# Altium schematic sheet PMIC.SchDoc(PMIC)
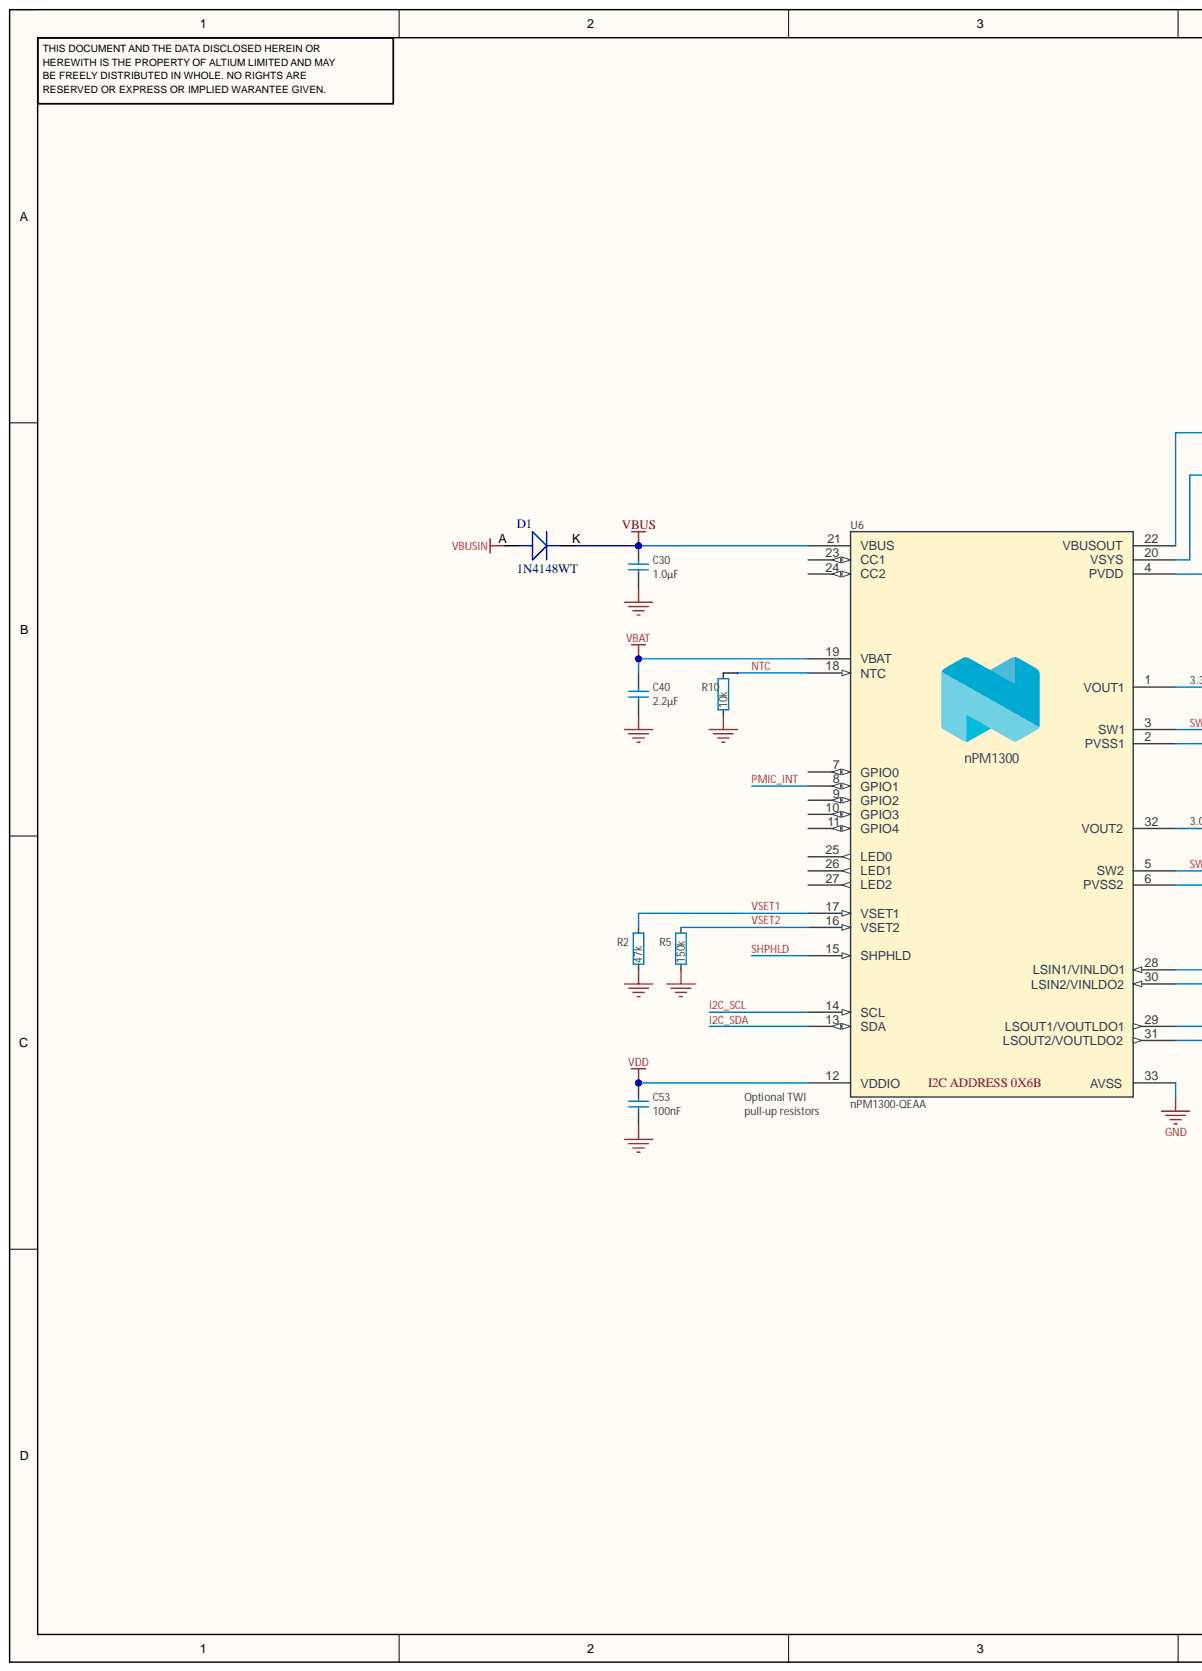
[diagram p0: PMIC.SchDoc, left]
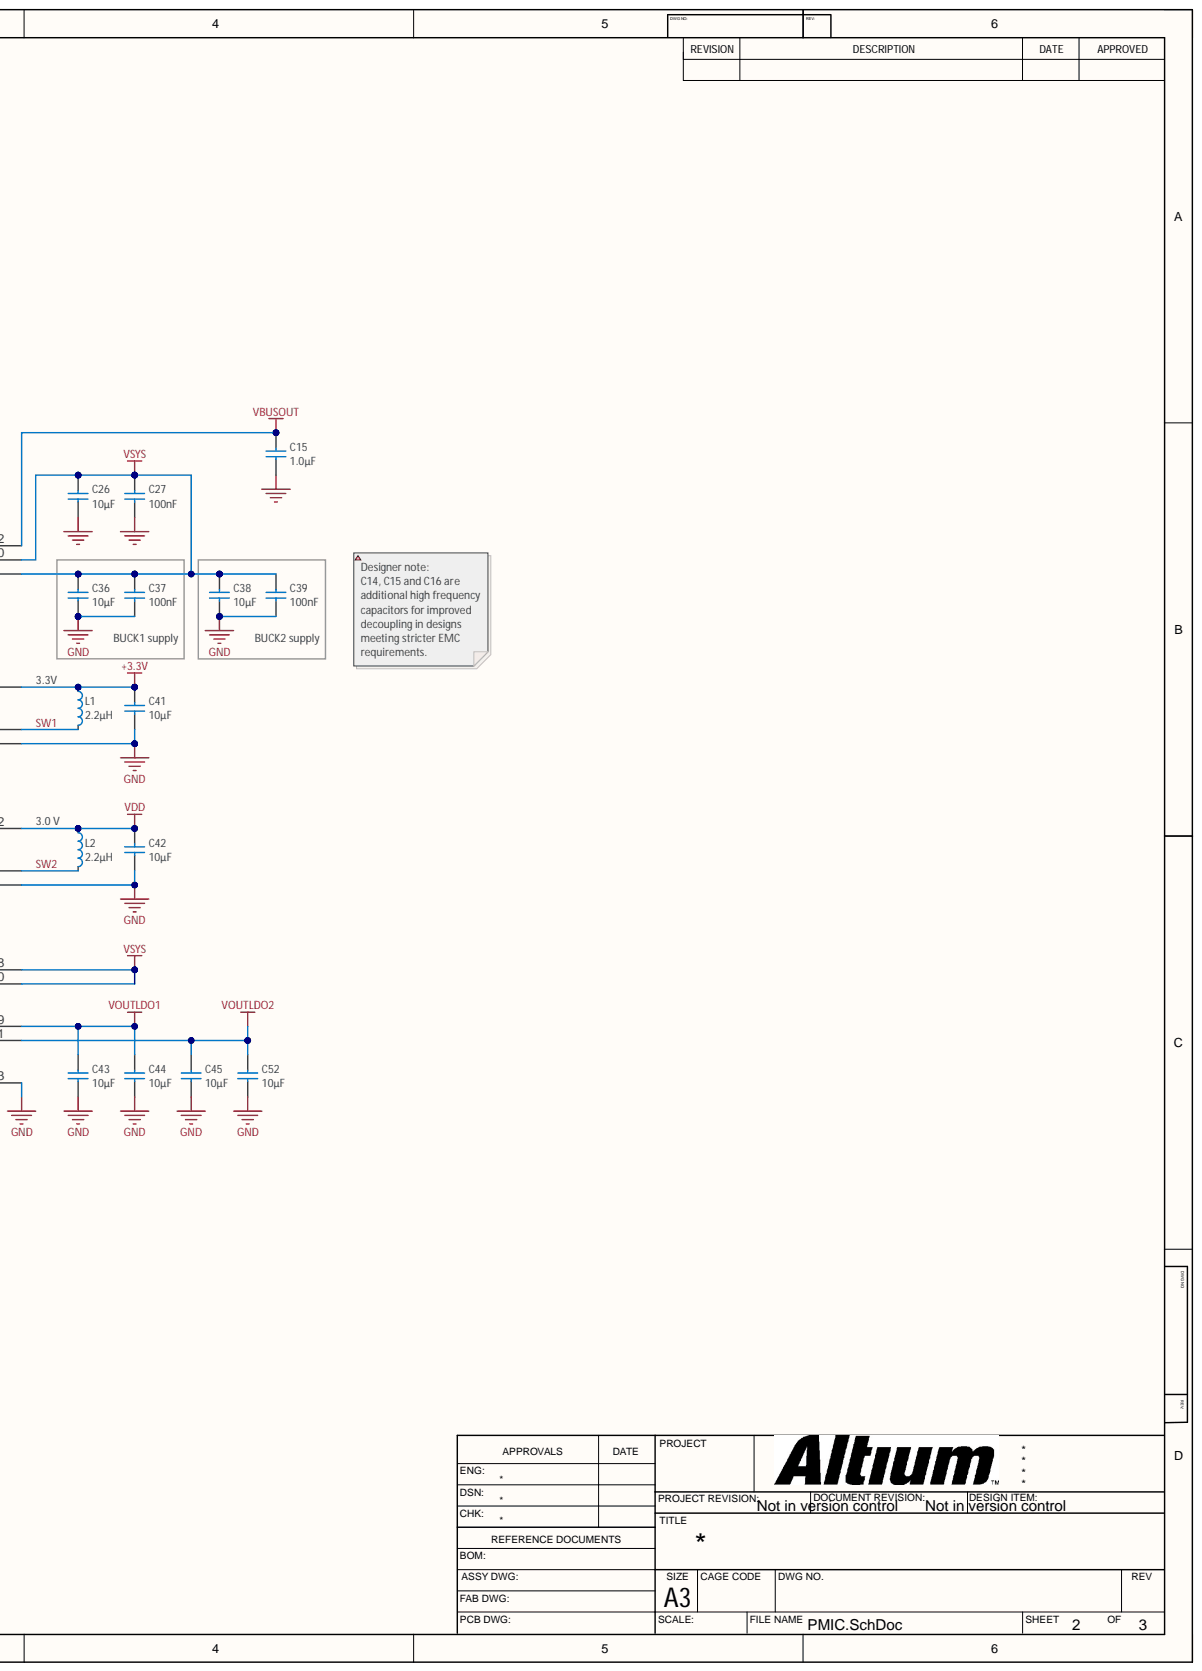
[diagram p1: PMIC.SchDoc, right]

## Parts
C15  1.0µF  [CAP_0402]
C26  10µF  [CAP_0402]
C27  100nF  [CAP_0402]
C30  1.0µF  [CAP_0402]
C36  10µF  [CAP_0402]
C37  100nF  [CAP_0402]
C38  10µF  [CAP_0402]
C39  100nF  [CAP_0402]
C40  2.2µF  [CAP_0402]
C41  10µF  [CAP_0402]
C42  10µF  [CAP_0402]
C43  10µF  [CAP_0402]
C44  10µF  [CAP_0402]
C45  10µF  [CAP_0402]
C52  10µF  [CAP_0402]
C53  100nF  [CAPC0603X03L_C]
D1  1N4148WT  [DIO_SOD523]
L1  2.2µH  [INDC2016X10N]
L2  2.2µH  [INDC2016X10N]
R2  47k  [RES_0402]
R5  150k  [RES_0402]
R10  10k  [RES_0402]
U6  nPM1300-QEAA  [Nordic_QFN50P500X500X90-33N]

## Nets
+3.3V: C41.2 L1.1 U6.1(VOUT1)
GND: C15.1 C26.1 C27.2 C30.1 C36.1 C37.2 C38.1 C39.2 C40.1 C41.1 C42.1 C43.1 C44.1 C45.1 C52.1 C53.2 R2.2 R5.2 R10.2 U6.2(PVSS1) U6.6(PVSS2) U6.33(AVSS)
VBAT: C40.2 U6.19(VBAT)
VBUS: C30.2 D1.K U6.21(VBUS)
VBUSIN: D1.A
VBUSOUT: C15.2 U6.22(VBUSOUT)
VDD: C42.2 C53.1 L2.1 U6.12(VDDIO) U6.32(VOUT2)
VOUTLDO1: C43.2 C44.2 U6.29(LSOUT1/VOUTLDO1)
VOUTLDO2: C45.2 C52.2 U6.31(LSOUT2/VOUTLDO2)
VSYS: C26.2 C27.1 C36.2 C37.1 C38.2 C39.1 U6.4(PVDD) U6.20(VSYS) U6.28(LSIN1/VINLDO1) U6.30(LSIN2/VINLDO2)
I2C_SCL: U6.14(SCL)
I2C_SDA: U6.13(SDA)
NTC: R10.1 U6.18(NTC)
PMIC_INT: U6.8(GPIO1)
SHPHLD: U6.15(SHPHLD)
SW1: L1.2 U6.3(SW1)
SW2: L2.2 U6.5(SW2)
VSET1: R2.1 U6.17(VSET1)
VSET2: R5.1 U6.16(VSET2)

Not connected: U6 7(GPIO0) 9(GPIO2) 10(GPIO3) 11(GPIO4) 23(CC1) 24(CC2) 25(LED0) 26(LED1) 27(LED2)

## Library data of the parts
C15 C30 = C0603-1µ0-10V-X5R: Capacitor, Ceramic, 1.0µF 10V X5R 0603,±10%; Part number NEMS: 100200528; Artikkelnr Noca: N.A.; Component Status: Active; Database Table Name: Parts$; Pad Count: 2; Component Class: Capacitors; Component Kind: Standard; ComponentLink1Description: Datasheet; ComponentLink1URL: N.A.; ComponentLink2Description: Supplier link; ComponentLink2URL: N.A.; Footprint Doc: 0603; HelpURL: N.A.; LatestRevisionDate: 2022-10-06; Library Name: AltiumLib.DbLib; Manufacturer: N.A.; Manufacturer Part Number: N.A.; Note: 10V; Part Number: C0603-1µ0-10V-X5R; Part number IPS: N.A.; Part number Norautron: N.A.; PartId: 100200528; Published: 2022-10-06; Supplier 1: N.A.; Supplier 2: N.A.; Supplier Part Number 1: N.A.; Supplier Part Number 2: N.A.; Value: 1.0µF; Author: getr
C26 C36 C38 C41-C45 C52 = C0603-10µ: Capacitor, X5R, ±20%; Manufacturer: N.A.; Part number IPS: 00000007785; Part number NEMS: 100200275; Artikkelnr Noca: 20039234; Component Status: Active; Database Table Name: Parts$; Note: 6.3V; Pad Count: 2; Component Class: Capacitors; Component Kind: Standard; ComponentLink1Description: Datasheet; ComponentLink1URL: N.A.; ComponentLink2Description: Supplier link; ComponentLink2URL: N.A.; Footprint Doc: 0603; HelpURL: N.A.; LatestRevisionDate: 2011-01-17; Library Name: AltiumLib.DbLib; Manufacturer Part Number: N.A.; Part Number: C0603-10µ; Part number Norautron: N.A.; PartId: 100200275; Published: 2011-01-17; Supplier 1: N.A.; Supplier 2: N.A.; Supplier Part Number 1: N.A.; Supplier Part Number 2: N.A.; Value: 10µF; Author: rsk
C27 C37 C39 = C0402-100n-16V-X7R-C0402C104M4RAC7867: Capacitor, Ceramic, X7R, ±20%, 16V; Manufacturer: Kemet; Part number NEMS: 100200504; Artikkelnr Noca: N.A.; Component Status: Active; Database Table Name: Parts$; Pad Count: 2; Component Class: Capacitors; Component Kind: Standard; ComponentLink1Description: Datasheet; ComponentLink1URL: https://api.kemet.com/component-edge/download/datasheet/C0402C104M4RACTU.pdf; ComponentLink2Description: Supplier link; ComponentLink2URL: https://www.digikey.no/no/products/detail/kemet/C0402C104M4RAC7867/2196240?s=N4IgTCBcDaIMIAYAsCxwIzILJIEoEE4B2ADgDYiQBdAXyA; Footprint Doc: 0402; HelpURL: https://www.kemet.com/en/us/capacitors/ceramic/kc-link/product/C0402C104M4RACTU.html; LatestRevisionDate: 2024-03-23; Library Name: AltiumLib.DbLib; Manufacturer Part Number: C0402C104M4RAC7867; Note: 16V; Part Number: C0402-100n-16V-X7R-C0402C104M4RAC7867; Part number IPS: N.A.; Part number Norautron: N.A.; PartId: 100200504; Published: 2022-03-23; Supplier 1: Digi-Key; Supplier 2: N.A.; Supplier Part Number 1: 399-C0402C104M4RAC7867CT-ND; Supplier Part Number 2: N.A.; Value: 100nF; Author: getr
C40 = C0603-2.2µ-16V-X7R: Capacitor, Ceramic, X7R, ±10%, 16V; Manufacturer: Taiyo Yuden; Manufacturer Part Number: EMK107BB7225KA-T; Part number NEMS: 100200439; Artikkelnr Noca: N.A.; Component Status: Active; Database Table Name: Parts$; Pad Count: 2; Component Class: Capacitors; Component Kind: Standard; ComponentLink1Description: Datasheet; ComponentLink1URL: https://ds.yuden.co.jp/TYCOMPAS/ut/specSheet?pn=EMK107BB7225KA-T; ComponentLink2Description: Supplier link; ComponentLink2URL: https://ds.yuden.co.jp/TYCOMPAS/ut/detail?pn=EMK107BB7225KA-T&u=M; Footprint Doc: 0603; HelpURL: https://www.digikey.no/product-detail/en/taiyo-yuden/EMK107BB7225KA-T/587-5835-2-ND/4970646; LatestRevisionDate: 2020-10-13; Library Name: AltiumLib.DbLib; Note: 16V; Part Number: C0603-2.2µ-16V-X7R; Part number IPS: N.A.; Part number Norautron: N.A.; PartId: 100200439; Published: 2020-02-03; Supplier 1: Digi-Key; Supplier 2: N.A.; Supplier Part Number 1: 587-5835-2-ND; Supplier Part Number 2: N.A.; Value: 2.2µF; Author: rsk
C53 = C0201-100n: Capacitor, X5R, ±10%, 100nF, 16V; Part number IPS: 00000001248, 00000002505; Part number NEMS: 100200078; Part number Norautron: 262071862; Artikkelnr Noca: N.A.; Component Status: Active; Database Table Name: Parts$; Pad Count: 2; Component Class: Capacitors; Component Kind: Standard; ComponentLink1Description: Datasheet; ComponentLink1URL: N.A.; ComponentLink2Description: Supplier link; ComponentLink2URL: N.A.; Footprint Doc: 0201; HelpURL: N.A.; LatestRevisionDate: 2024-06-27; Library Name: AltiumLib.DbLib; Manufacturer: N.A.; Manufacturer Part Number: N.A.; Note: 16V; Part Number: C0201-100n; PartId: 100200078; Published: 2014-11-13; Supplier 1: N.A.; Supplier 2: N.A.; Supplier Part Number 1: N.A.; Supplier Part Number 2: N.A.; Value: 100nF; Author: rsk
D1 = DIO_75V-200mA-SOD-523F: Diode Standard 100V 300mA (DC) Surface Mount SOD-123; Manufacturer: FAIRCHILD; Case/Package: SOD123; Component Kind: Standard; Manufacturer Part Number: 1N4148WT; Supplier-1: SIRICOM; Library Name: EsteemPCB_SOM_Course_schlib.SchLib
L1 L2 = L0806-2µ2-2500mA-73mOhm-CIGT201610EH2R2MNE: Inductor, Irms=2.5A, Isat=2.9A, ±20%, DCR=73mΩ; Manufacturer: Samsung Electro-Mechanics America; Part number NEMS: 100300400; Part number Norautron: 26521789; Artikkelnr Noca: N.A.; Component Status: Active; Database Table Name: Parts$; Note: Unshielded Thin Film Inductor; Pad Count: 2; Component Class: Inductors; Component Kind: Standard; ComponentLink1Description: Datasheet; ComponentLink1URL: https://media.digikey.com/pdf/Data%20Sheets/Samsung%20PDFs/CIGT201610EH2R2MNE_Spec.pdf; ComponentLink2Description: Supplier link; ComponentLink2URL: https://www.digikey.com/en/products/detail/samsung-electro-mechanics/CIGT201610EH2R2MNE/7041223?s=N4IgTCBcDaIMIEkDiAVMAGAjANk%2BgogBJgBKYAsgHL4gC6AvkA; Footprint Doc: 0806; HelpURL: http://product.samsungsem.com/pi/CIGT201610EH2R2MN.do; LatestRevisionDate: 2022-09-07; Library Name: AltiumLib.DbLib; Manufacturer Part Number: CIGT201610EH2R2MNE; Part Number: L0806-2µ2-2500mA-73mOhm-CIGT201610EH2R2MNE; Part number IPS: N.A.; PartId: 100300400; Published: 2021-02-03; Supplier 1: Digi-Key; Supplier 2: Mouser; Supplier Part Number 1: 1276-6901-1-ND; Supplier Part Number 2: 187-CIGT201610EH2R2M; Value: 2.2µH; Author: rsk
R2 = R0201-47k: Resistor, ±1%, 0.05W; Part number IPS: 00000001423; Part number NEMS: 100100076; Artikkelnr Noca: N.A.; Component Status: Active; Database Table Name: Parts$; Note: Chip Res 1%; Pad Count: 2; Component Class: Resistors; Component Kind: Standard; ComponentLink1Description: Datasheet; ComponentLink1URL: N.A.; ComponentLink2Description: Supplier link; ComponentLink2URL: N.A.; Footprint Doc: 0201; HelpURL: N.A.; LatestRevisionDate: 2014-11-13; Library Name: AltiumLib.DbLib; Manufacturer: N.A.; Manufacturer Part Number: N.A.; Part Number: R0201-47k; Part number Norautron: N.A.; PartId: 100100076; Published: 2014-11-13; Supplier 1: N.A.; Supplier 2: N.A.; Supplier Part Number 1: N.A.; Supplier Part Number 2: N.A.; Value: 47k; Author: rsk
R5 = R0201-150k: Resistor, ±1%, 0.05W; Part number IPS: 00000001422; Part number NEMS: 100100082; Artikkelnr Noca: N.A.; Component Status: Active; Database Table Name: Parts$; Note: Chip Res 1%; Pad Count: 2; Component Class: Resistors; Component Kind: Standard; ComponentLink1Description: Datasheet; ComponentLink1URL: N.A.; ComponentLink2Description: Supplier link; ComponentLink2URL: N.A.; Footprint Doc: 0201; HelpURL: N.A.; LatestRevisionDate: 2014-11-13; Library Name: AltiumLib.DbLib; Manufacturer: N.A.; Manufacturer Part Number: N.A.; Part Number: R0201-150k; Part number Norautron: N.A.; PartId: 100100082; Published: 2014-11-13; Supplier 1: N.A.; Supplier 2: N.A.; Supplier Part Number 1: N.A.; Supplier Part Number 2: N.A.; Value: 150k; Author: rsk
R10 = R0201-10k: Resistor, ±1%, 0.05W; Part number IPS: 00000001255; Part number NEMS: 100100065; Part number Norautron: 26107101; Artikkelnr Noca: N.A.; Component Status: Active; Database Table Name: Parts$; Note: Chip Res 1%; Pad Count: 2; Component Class: Resistors; Component Kind: Standard; ComponentLink1Description: Datasheet; ComponentLink1URL: N.A.; ComponentLink2Description: Supplier link; ComponentLink2URL: N.A.; Footprint Doc: 0201; HelpURL: N.A.; LatestRevisionDate: 2014-11-13; Library Name: AltiumLib.DbLib; Manufacturer: N.A.; Manufacturer Part Number: N.A.; Part Number: R0201-10k; PartId: 100100065; Published: 2014-11-13; Supplier 1: N.A.; Supplier 2: N.A.; Supplier Part Number 1: N.A.; Supplier Part Number 2: N.A.; Value: 10k; Author: rsk
U6 = nPM1300-QEAA: Li-Ion/Li-Polymer USB-C battery charger with high efficiency buck regulators, LDOs or load switches, LED drivers, TWI and VBUSOUT; Manufacturer: Nordic Semiconductor; Part number NEMS: 102000291; Artikkelnr Noca: N.A.; Component Status: Active; Database Table Name: Parts$; Pad Count: 33; Component Class: Nordic_Devices; Component Kind: Standard; ComponentLink1Description: Datasheet; ComponentLink1URL: N.A.; ComponentLink2Description: Supplier link; ComponentLink2URL: N.A.; Footprint Doc: QFN-32; HelpURL: N.A.; LatestRevisionDate: 2023-05-25; Library Name: AltiumLib.DbLib; Manufacturer Part Number: nPM1300-QEAA; Note: N.A.; Part Number: nPM1300-QEAA; Part number IPS: N.A.; Part number Norautron: N.A.; PartId: 102000291; Published: 2022-02-17; Supplier 1: Nordic Semiconductor; Supplier 2: N.A.; Supplier Part Number 1: nPM1300-QEAA; Supplier Part Number 2: N.A.; Value: nPM1300-QEAA; Author: eisk
